# Altium schematic sheet MAIN-VOLTMETER.SchDoc
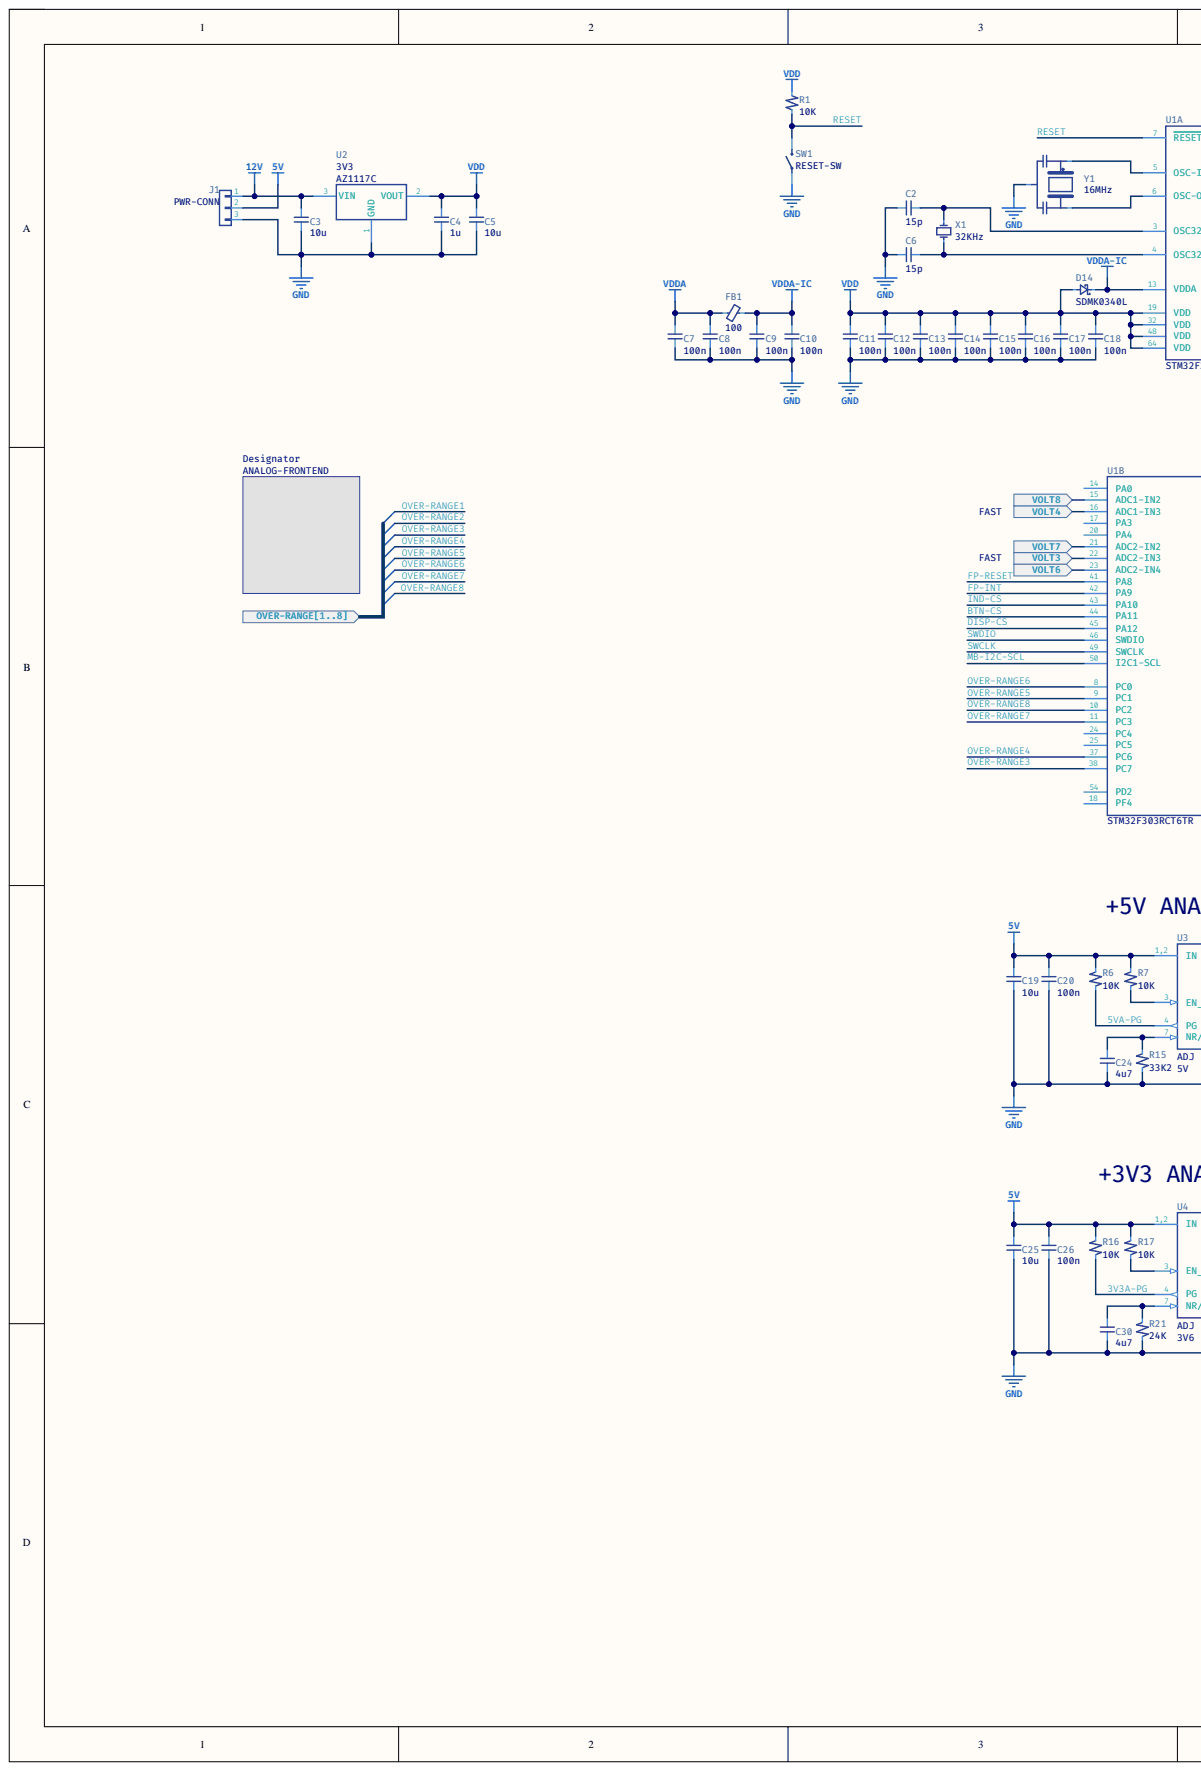
[diagram p0: MAIN-VOLTMETER.SchDoc, left]
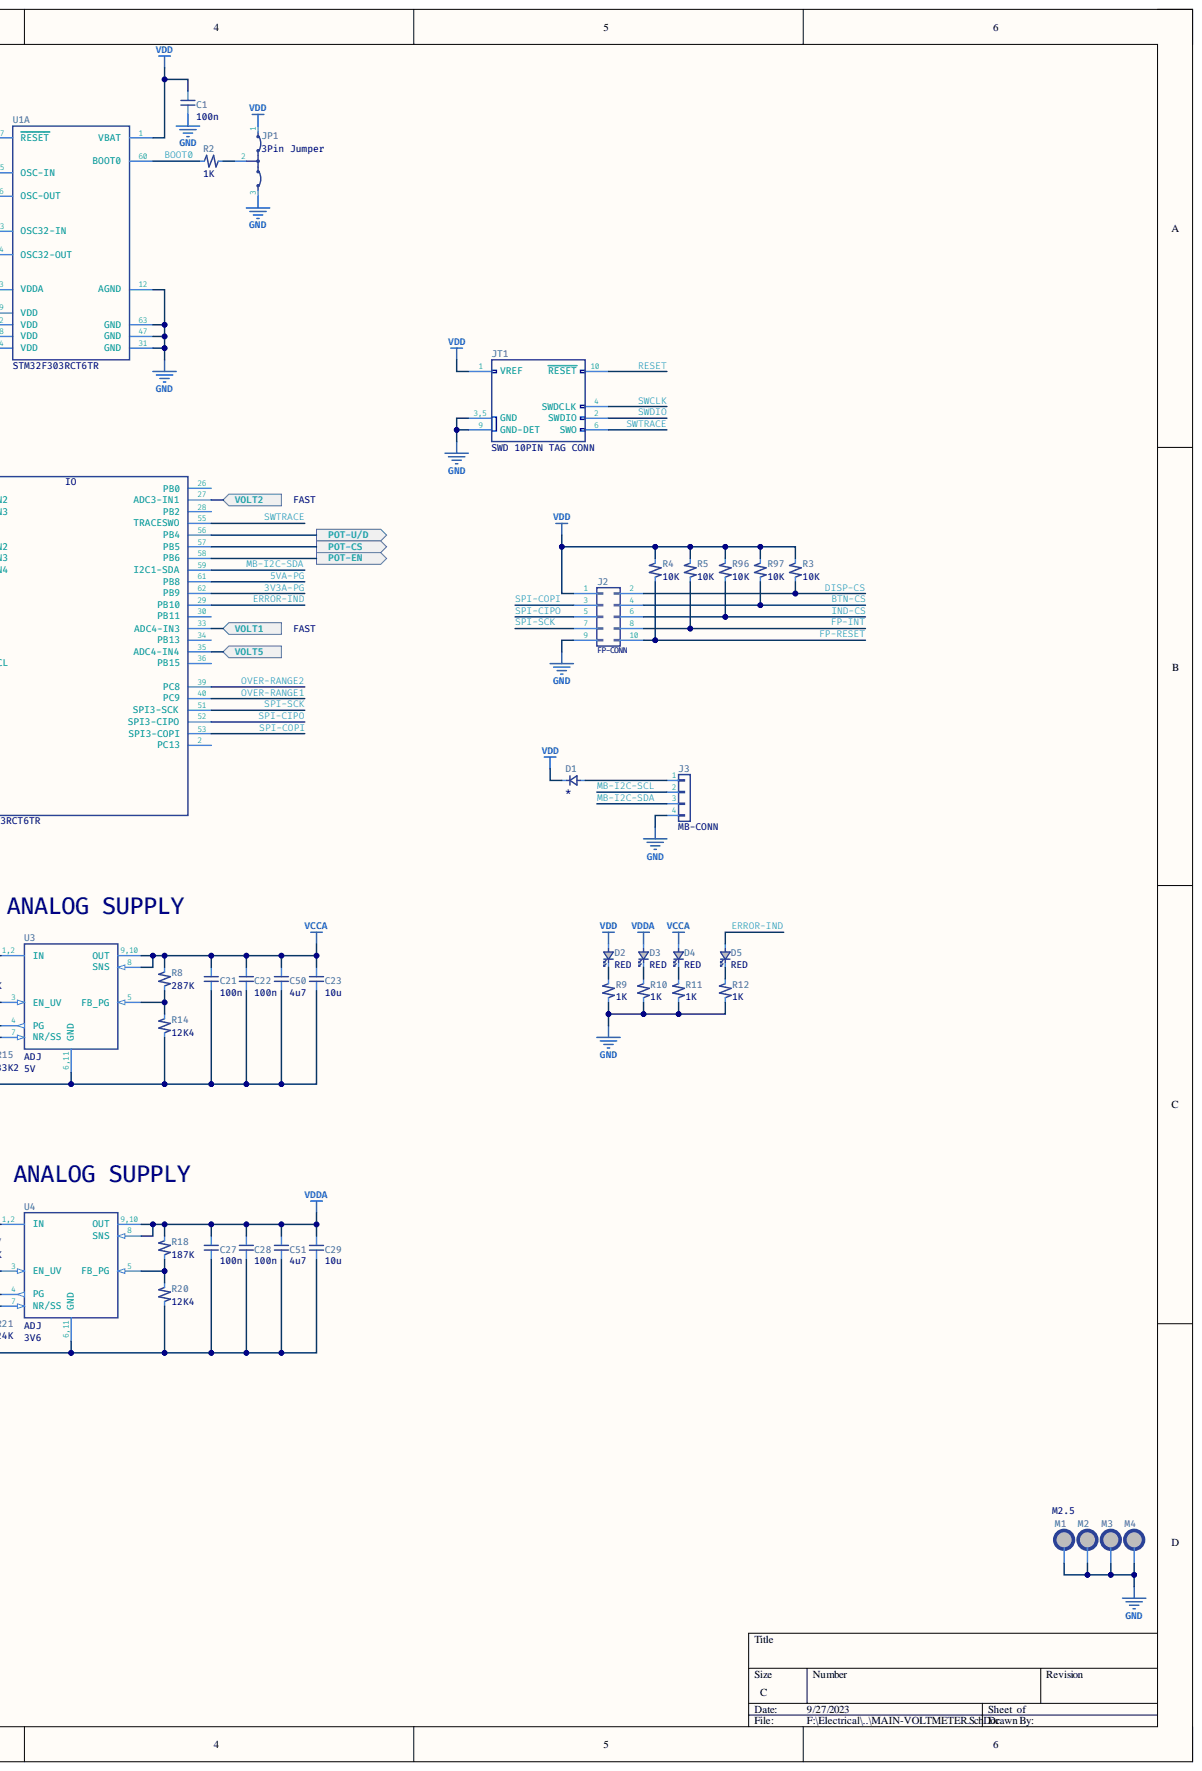
[diagram p1: MAIN-VOLTMETER.SchDoc, right]

## Parts
C1  value 100n  [CAP-0402]  (hidden comment: Generic Capacitor)
C2  value 15p  [CAP-0402]  (hidden comment: Generic Capacitor)
C3  value 10u  [CAP-1210]  (hidden comment: Generic Capacitor)
C4  value 1u  [CAP-0805]  (hidden comment: Generic Capacitor)
C5  value 10u  [CAP-1210]  (hidden comment: Generic Capacitor)
C6  value 15p  [CAP-0402]  (hidden comment: Generic Capacitor)
C7  value 100n  [CAP-0402]  (hidden comment: Generic Capacitor)
C8  value 100n  [CAP-0402]  (hidden comment: Generic Capacitor)
C9  value 100n  [CAP-0402]  (hidden comment: Generic Capacitor)
C10  value 100n  [CAP-0402]  (hidden comment: Generic Capacitor)
C11  value 100n  [CAP-0402]  (hidden comment: Generic Capacitor)
C12  value 100n  [CAP-0402]  (hidden comment: Generic Capacitor)
C13  value 100n  [CAP-0402]  (hidden comment: Generic Capacitor)
C14  value 100n  [CAP-0402]  (hidden comment: Generic Capacitor)
C15  value 100n  [CAP-0402]  (hidden comment: Generic Capacitor)
C16  value 100n  [CAP-0402]  (hidden comment: Generic Capacitor)
C17  value 100n  [CAP-0402]  (hidden comment: Generic Capacitor)
C18  value 100n  [CAP-0402]  (hidden comment: Generic Capacitor)
C19  value 10u  [CAP-1210]  (hidden comment: Generic Capacitor)
C20  value 100n  [CAP-0402]  (hidden comment: Generic Capacitor)
C21  value 100n  [CAP-0402]  (hidden comment: Generic Capacitor)
C22  value 100n  [CAP-0402]  (hidden comment: Generic Capacitor)
C23  value 10u  [CAP-1210]  (hidden comment: Generic Capacitor)
C24  value 4u7  [CAP-0805]  (hidden comment: Generic Capacitor)
C25  value 10u  [CAP-1210]  (hidden comment: Generic Capacitor)
C26  value 100n  [CAP-0402]  (hidden comment: Generic Capacitor)
C27  value 100n  [CAP-0402]  (hidden comment: Generic Capacitor)
C28  value 100n  [CAP-0402]  (hidden comment: Generic Capacitor)
C29  value 10u  [CAP-1210]  (hidden comment: Generic Capacitor)
C30  value 4u7  [CAP-0805]  (hidden comment: Generic Capacitor)
C50  value 4u7  [CAP-0805]  (hidden comment: Generic Capacitor)
C51  value 4u7  [CAP-0805]  (hidden comment: Generic Capacitor)
D1  General Purpose Diode  [D_SMA]  (value not drawn)
D2  Color: RED  [LED-0603]  (hidden comment: General LED)
D3  Color: RED  [LED-0603]  (hidden comment: General LED)
D4  Color: RED  [LED-0603]  (hidden comment: General LED)
D5  Color: RED  [LED-0603]  (hidden comment: General LED)
D14  value SDMK0340L  [D-SOD-323]  (hidden comment: DIODE SCHOTTKY 40V 30MA SOD323)
FB1  value 100  [IND-0603]  (hidden comment: Generic Ferrite Bead)
J1  PWR CONN  value PWR-CONN  [JST_XH_B3B-XH-A_1x03_P2.50mm_Vertical]
J2  value FP-CONN  (hidden comment: Front Panel Connector)
J3  value MB-CONN  [JST_XH_B4B-XH-A_1x04_P2.50mm_Vertical]  (hidden comment: Motherboard Comms Connector)
JP1  value 3Pin Jumper  [PinHeader_1x03_P2.54mm_Vertical]  (hidden comment: Generic 3 Pin Jumper)
JT1  value SWD 10PIN TAG CONN  [Tag-Connect_TC2050-IDC-FP_2x05_P1.27mm_Vertical]  (hidden comment: SWD-TAG-10)
M1  value M2.5  [PTH-MOUNT-M2.5]  (hidden comment: Plated Mounting Hole)
M2  value M2.5  [PTH-MOUNT-M2.5]  (hidden comment: Plated Mounting Hole)
M3  Plated Mounting Hole  [PTH-MOUNT-M2.5]  (value not drawn)
M4  Plated Mounting Hole  [PTH-MOUNT-M2.5]  (value not drawn)
R1  value 10K  [RES-0402]  (hidden comment: General Resistor)
R2  value 1K  [RES-0402]  (hidden comment: General Resistor)
R3  value 10K  [RES-0402]  (hidden comment: General Resistor)
R4  value 10K  [RES-0402]  (hidden comment: General Resistor)
R5  value 10K  [RES-0402]  (hidden comment: General Resistor)
R6  value 10K  [RES-0402]  (hidden comment: General Resistor)
R7  value 10K  [RES-0402]  (hidden comment: General Resistor)
R8  value 287K  [RES-0402]  (hidden comment: General Resistor)
R9  value 1K  [RES-0402]  (hidden comment: General Resistor)
R10  value 1K  [RES-0402]  (hidden comment: General Resistor)
R11  value 1K  [RES-0402]  (hidden comment: General Resistor)
R12  value 1K  [RES-0402]  (hidden comment: General Resistor)
R14  value 12K4  [RES-0402]  (hidden comment: General Resistor)
R15  value 33K2  [RES-0402]  (hidden comment: General Resistor)
R16  value 10K  [RES-0402]  (hidden comment: General Resistor)
R17  value 10K  [RES-0402]  (hidden comment: General Resistor)
R18  value 187K  [RES-0402]  (hidden comment: General Resistor)
R20  value 12K4  [RES-0402]  (hidden comment: General Resistor)
R21  value 24K  [RES-0402]  (hidden comment: General Resistor)
R96  value 10K  [RES-0402]  (hidden comment: General Resistor)
R97  value 10K  [RES-0402]  (hidden comment: General Resistor)
SW1  value RESET-SW  [TL3365AF180QG]  (hidden comment: Generic STSP Switch)
U1  value STM32F303RCT6TR  [LQFP-64_10x10mm_P0.5mm]  (hidden comment: IC MCU 32BIT 256KB FLASH)  (parts A,B)
U2  value AZ1117C  VOUT: 3V3  [SOT-223-3_TabPin2]  (hidden comment: IC REG LINEAR 3.3V 1A)
U3  Output: 5V  UVLO: ADJ  [WSON-10-1EP_3x3mm_Therm-Vias]  (hidden comment: IC LIN REG 1-A, ULTRA-LOW NOISE)
U4  Output: 3V6  UVLO: ADJ  [WSON-10-1EP_3x3mm_Therm-Vias]  (hidden comment: IC LIN REG 1-A, ULTRA-LOW NOISE)
X1  Frequency: 32KHz  [CRYST-2-3.2x1.5mm]  (hidden comment: Crystal Floating)
Y1  value 16MHz  [RESO-3-3x1.1mm]  (hidden comment: General 3P Resonator)

## Ports
OVER-RANGE1, OVER-RANGE2, OVER-RANGE3, OVER-RANGE4, OVER-RANGE5, OVER-RANGE6, OVER-RANGE7, OVER-RANGE8, OVER-RANGE[1..8], POT-CS, POT-EN, POT-U/D, VOLT1, VOLT2, VOLT3, VOLT4, VOLT5, VOLT6, VOLT7, VOLT8

## Nets
5V: C19.1 C20.1 C25.1 C26.1 J1.2 R6.2 R7.2 R16.2 R17.2 U3.1,2(IN) U4.1,2(IN)
12V: C3.1 J1.1 U2.3
GND: C1.2 C2.2 C3.2 C4.2 C5.2 C6.2 C7.2 C8.2 C9.2 C10.2 C11.2 C12.2 C13.2 C14.2 C15.2 C16.2 C17.2 C18.2 C19.2 C20.2 C21.2 C22.2 C23.2 C24.2 C25.2 C26.2 C27.2 C28.2 C29.2 C30.2 C50.2 C51.2 J1.3 J2.9 J3.4 JP1.3 JT1.3,5(GND) JT1.9(GND-DET) M1.1 M2.1 M3.1 M4.1 R9.1 R10.1 R11.1 R12.1 R14.1 R15.1 R20.1 R21.1 SW1.1 U1.12(AGND) U1.31(GND) U1.47(GND) U1.63(GND) U2.1 U3.6,11(GND) U4.6,11(GND) Y1.2
VCCA: C21.1 C22.1 C23.1 C50.1 D4.2 R8.2 U3.8(SNS) U3.9,10(OUT)
VDD: C1.1 C4.1 C5.1 C11.1 C12.1 C13.1 C14.1 C15.1 C16.1 C17.1 C18.1 D1.1 D2.2 D14.2 J2.1 JP1.1 JT1.1(VREF) R1.2 R3.2 R4.2 R5.2 R96.2 R97.2 U1.1(VBAT) U1.19(VDD) U1.32(VDD) U1.48(VDD) U1.64(VDD) U2.2
VDDA: C7.1 C8.1 C27.1 C28.1 C29.1 C51.1 D3.2 FB1.1 R18.2 U4.8(SNS) U4.9,10(OUT)
VDDA-IC: C9.1 C10.1 D14.1 FB1.2 U1.13(VDDA)
3V3A-PG: R16.1 U1.62(PB9) U4.4(PG)
5VA-PG: R6.1 U1.61(PB8) U3.4(PG)
BOOT0: R2.1 U1.60(BOOT0)
BTN-CS: J2.4 R97.1 U1.44(PA11)
DISP-CS: J2.2 R3.1 U1.45(PA12)
ERROR-IND: D5.2 U1.29(PB10)
FP-INT: J2.8 R5.1 U1.42(PA9)
FP-RESET: J2.10 R4.1 U1.41(PA8)
IND-CS: J2.6 R96.1 U1.43(PA10)
MB-I2C-SCL: J3.2 U1.50(I2C1-SCL)
MB-I2C-SDA: J3.3 U1.59(I2C1-SDA)
OVER-RANGE1: U1.40(PC9) ; port OVER-RANGE[1..8]  [also labelled ]
OVER-RANGE2: U1.39(PC8) ; port OVER-RANGE[1..8]  [also labelled ]
OVER-RANGE3: U1.38(PC7) ; port OVER-RANGE[1..8]  [also labelled ]
OVER-RANGE4: U1.37(PC6) ; port OVER-RANGE[1..8]  [also labelled ]
OVER-RANGE5: U1.9(PC1) ; port OVER-RANGE[1..8]  [also labelled ]
OVER-RANGE6: U1.8(PC0) ; port OVER-RANGE[1..8]  [also labelled ]
OVER-RANGE7: U1.11(PC3) ; port OVER-RANGE[1..8]  [also labelled ]
OVER-RANGE8: U1.10(PC2) ; port OVER-RANGE[1..8]  [also labelled ]
POT-CS: U1.57(PB5) ; port POT-CS
POT-EN: U1.58(PB6) ; port POT-EN
POT-U/D: U1.56(PB4) ; port POT-U/D
RESET: JT1.10(RESET) R1.1 SW1.2 U1.7(RESET)
SPI-CIPO: J2.5 U1.52(SPI3-CIPO)
SPI-COPI: J2.3 U1.53(SPI3-COPI)
SPI-SCK: J2.7 U1.51(SPI3-SCK)
SWCLK: JT1.4(SWDCLK) U1.49(SWCLK)
SWDIO: JT1.2(SWDIO) U1.46(SWDIO)
SWTRACE: JT1.6(SWO) U1.55(TRACESWO)
VOLT1: U1.33(ADC4-IN3) ; port VOLT1
VOLT2: U1.27(ADC3-IN1) ; port VOLT2
VOLT3: U1.22(ADC2-IN3) ; port VOLT3
VOLT4: U1.16(ADC1-IN3) ; port VOLT4
VOLT5: U1.35(ADC4-IN4) ; port VOLT5
VOLT6: U1.23(ADC2-IN4) ; port VOLT6
VOLT7: U1.21(ADC2-IN2) ; port VOLT7
VOLT8: U1.15(ADC1-IN2) ; port VOLT8
NetC2_1: C2.1 U1.3(OSC32-IN) X1.2
NetC6_1: C6.1 U1.4(OSC32-OUT) X1.1
NetC24_1: C24.1 R15.2 U3.7(NR/SS)
NetC30_1: C30.1 R21.2 U4.7(NR/SS)
NetD1_2: D1.2 J3.1
NetD2_1: D2.1 R9.2
NetD3_1: D3.1 R10.2
NetD4_1: D4.1 R11.2
NetD5_1: D5.1 R12.2
NetJP1_2: JP1.2 R2.2
NetR7_1: R7.1 U3.3(EN_UV)
NetR8_1: R8.1 R14.2 U3.5(FB_PG)
NetR17_1: R17.1 U4.3(EN_UV)
NetR18_1: R18.1 R20.2 U4.5(FB_PG)
NetU1_5: U1.5(OSC-IN) Y1.1
NetU1_6: U1.6(OSC-OUT) Y1.3

Not connected: U1 2(PC13) 14(PA0) 17(PA3) 18(PF4) 20(PA4) 24(PC4) 25(PC5) 26(PB0) 28(PB2) 30(PB11) 34(PB13) 36(PB15) 54(PD2)

## Library data of the parts
C1-C30 C50 C51 = C: General un-polarized capacitor; Tolerance: 5%; Component Kind: Standard; Library Name: Daxxn_Device.SchLib
D1 = D-GP: General purpose doide; Component Kind: Standard; ComponentLink1Description: Datasheet; ComponentLink2Description: LocalDatasheet; Library Name: Daxxn_Device.SchLib
D2-D5 = LED: Component Kind: Standard; ComponentLink1Description: Datasheet; ComponentLink2Description: LocalDatasheet; Description: General LED; Library Name: Daxxn_Device.SchLib
D14 = D-SK: Diode 40 V 30mA Surface Mount SOD-323; VF: 370mA; Component Kind: Standard; ComponentLink1Description: Datasheet; ComponentLink1URL: https://www.diodes.com/assets/Datasheets/ds30239.pdf; ComponentLink2Description: LocalDatasheet; Library Name: Daxxn_Device.SchLib
FB1 = FerriteBead: Component Kind: Standard; Description: Generic Ferrite Bead; Library Name: Daxxn_Device.SchLib
J1 = Conn_01x03: Component Kind: Standard; ComponentLink1Description: Datasheet; ComponentLink2Description: LocalDatasheet; Library Name: Connector_Generic.SchLib
J2 = Conn_02x05_Odd_Even: Component Kind: Standard; Library Name: Connector_Generic.SchLib
J3 = Conn_01x04: Component Kind: Standard; ComponentLink1Description: Datasheet; ComponentLink2Description: LocalDatasheet; Library Name: Connector_Generic.SchLib
JP1 = Jumper-3Pin: Component Kind: Standard; ComponentLink1Description: Datasheet; ComponentLink2Description: LocalDatasheet; Description: Generic 3 Pin Jumper; Library Name: Daxxn_Device.SchLib
M1 M2 = MountingHole-PTH: Generic Plated Mounting Through Hole; Component Kind: Standard; ComponentLink1Description: Datasheet; ComponentLink2Description: LocalDatasheet; Library Name: Daxxn_Device.SchLib
M3 M4 = MountingHole-PTH: Generic Plated Mounting Through Hole; Value: M2.5; Component Kind: Standard; ComponentLink1Description: Datasheet; ComponentLink2Description: LocalDatasheet; Library Name: Daxxn_Device.SchLib
R1-R12 R14-R18 R20 R21 R96 R97 = R: General purpose resistor; Tolerance: 1%; Component Kind: Standard; Library Name: Daxxn_Device.SchLib
SW1 = Switch-STSP: Component Kind: Standard; Description: Generic STSP Switch; Library Name: Daxxn_Device.SchLib
U1 = STM32F303RCT6TR: ARM Cortex-M4 STM32F3 Microcontroller IC 32-Bit Single-Core 72MHz 256KB (256K x 8) FLASH LQFP-64; Component Kind: Standard; ComponentLink1Description: Datasheet; ComponentLink1URL: https://www.st.com/content/ccc/resource/technical/document/datasheet/f2/1f/e1/41/ef/59/4d/50/DM00058181.pdf/files/DM00058181.pdf/jcr:content/translations/en.DM00058181.pdf; ComponentLink2Description: LocalDatasheet; Supplier 1: Digi-Key; Supplier 2: Digi-Key; Supplier 3: Digi-Key; Supplier 4: Arrow Electronics; Supplier 5: Mouser; Supplier 6: Avnet; Supplier Part Number 1: 497-19002-6-ND; Supplier Part Number 2: 497-19002-1-ND; Supplier Part Number 3: 497-19002-2-ND; Supplier Part Number 4: STM32F303RCT6TR; Supplier Part Number 5: 511-STM32F303RCT6TR; Supplier Part Number 6: STM32F303RCT6TR; ComponentLink2URL: F:\Electrical\Datasheets\STM32F303xC.pdf; Library Name: Daxxn_MicroControllers.SchLib
U2 = AZ1117C: Linear Voltage Regulator IC Positive Fixed 1 Output 1A; Component Kind: Standard; ComponentLink1Description: Datasheet; ComponentLink1URL: https://www.diodes.com/assets/Datasheets/AZ1117C.pdf; ComponentLink2Description: LocalDatasheet; Supplier 1: Digi-Key; Supplier 2: Digi-Key; Supplier 3: Mouser; Supplier 4: Newark; Supplier Part Number 1: 31-AZ1117CH2-3.3TRG1CT-ND; Supplier Part Number 2: 31-AZ1117CH2-3.3TRG1DKR-ND; Supplier Part Number 3: 621-AZ1117CH2-33TRG1; Supplier Part Number 4: 76AH4966; ComponentLink2URL: F:\Electrical\Datasheets\AZ1117C.pdf; Library Name: Daxxn_Regulators.SchLib
U3 U4 = TPS7A94: Linear Voltage Regulator IC Positive Adjustable 1A WSON-10; Component Kind: Standard; ComponentLink1Description: Datasheet; ComponentLink1URL: https://www.ti.com/lit/ds/symlink/tps7a94.pdf; ComponentLink2Description: LocalDatasheet; Supplier 1: Digi-Key; Supplier 2: Digi-Key; Supplier Part Number 1: 296-TPS7A9401DSCRCT-ND; Supplier Part Number 2: 296-TPS7A9401DSCRDKR-ND; ComponentLink2URL: F:\Electrical\Datasheets\TPS7A94.pdf; Library Name: Daxxn_Regulators.SchLib
X1 = Crystal-Floating: Crystal oscillator without a ground connection; Component Kind: Standard; Library Name: Daxxn_Device.SchLib
Y1 = Resonator: General 3-Pin Resonator; Tolerance: 15ppm; Component Kind: Standard; ComponentLink1Description: Datasheet; ComponentLink2Description: LocalDatasheet; Library Name: Daxxn_Device.SchLib
JT1 = SWD-TAG-10: Component Kind: Standard; ComponentLink1Description: Datasheet; ComponentLink2Description: LocalDatasheet; Library Name: Daxxn_Connectors.SchLib
